# Altium schematic sheet Sheet1.SchDoc(Sheet1)
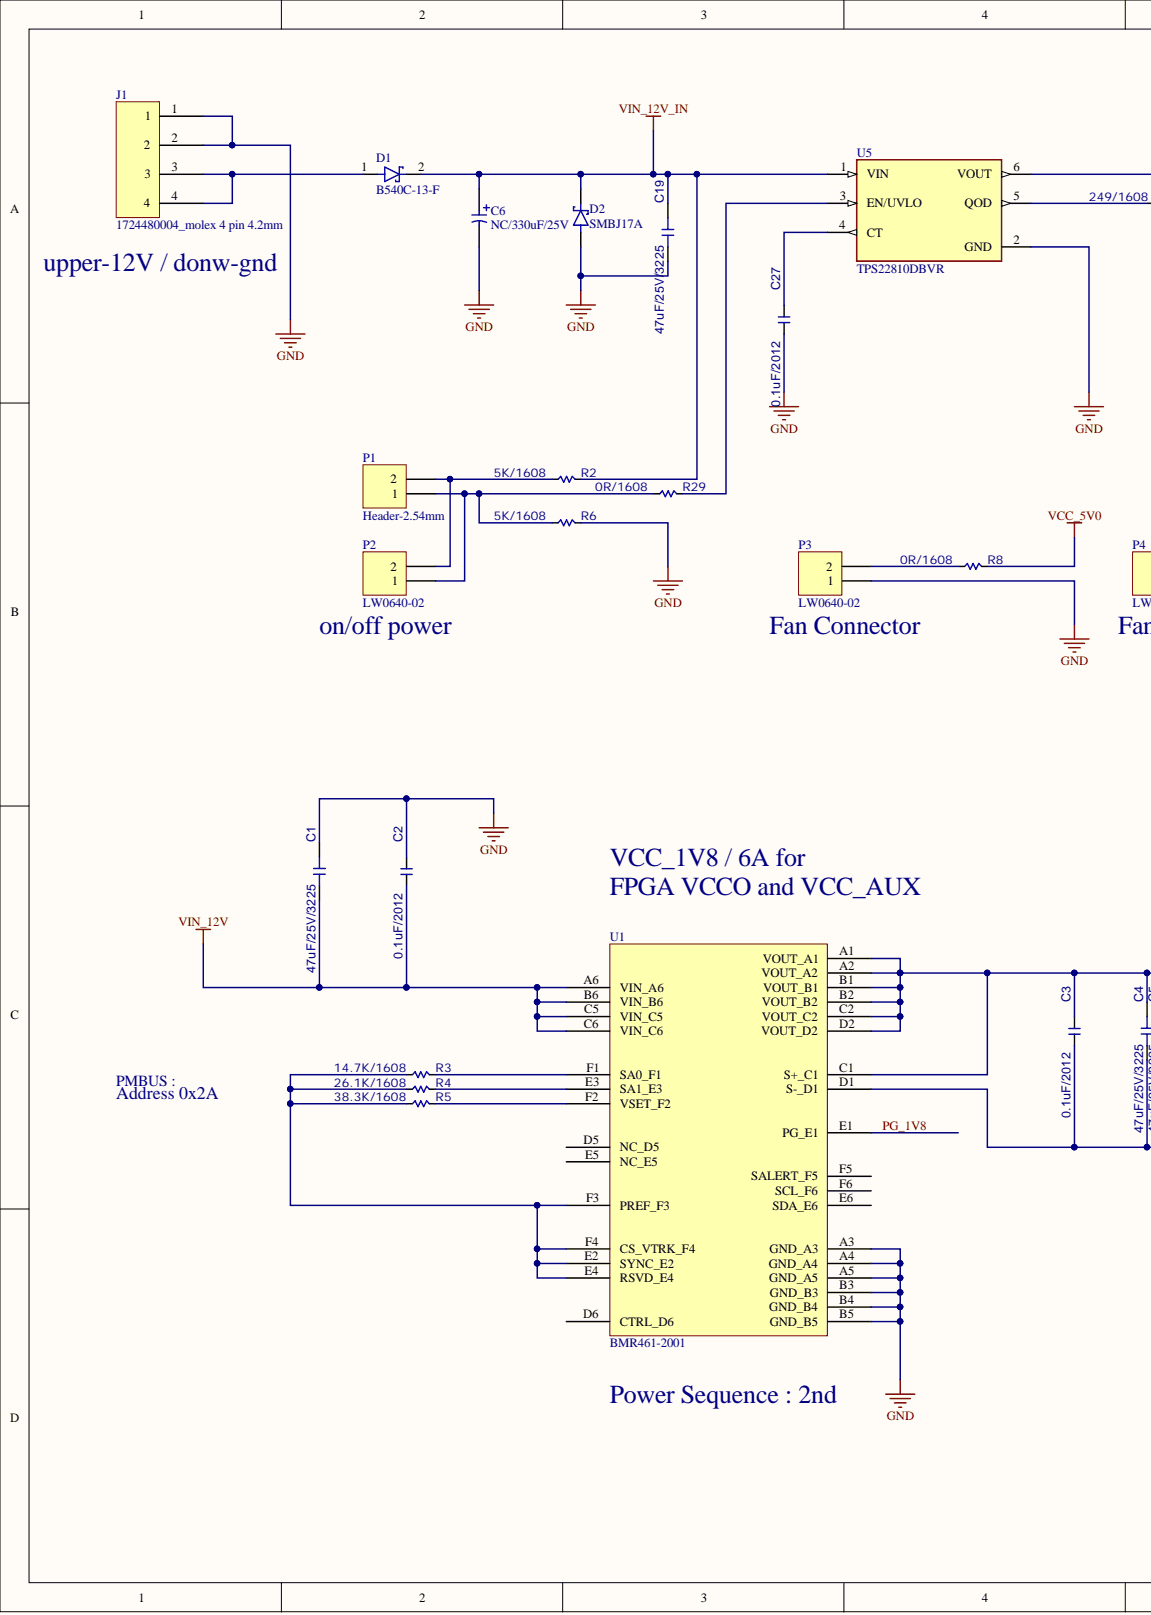
[diagram p0: Sheet1.SchDoc, left]
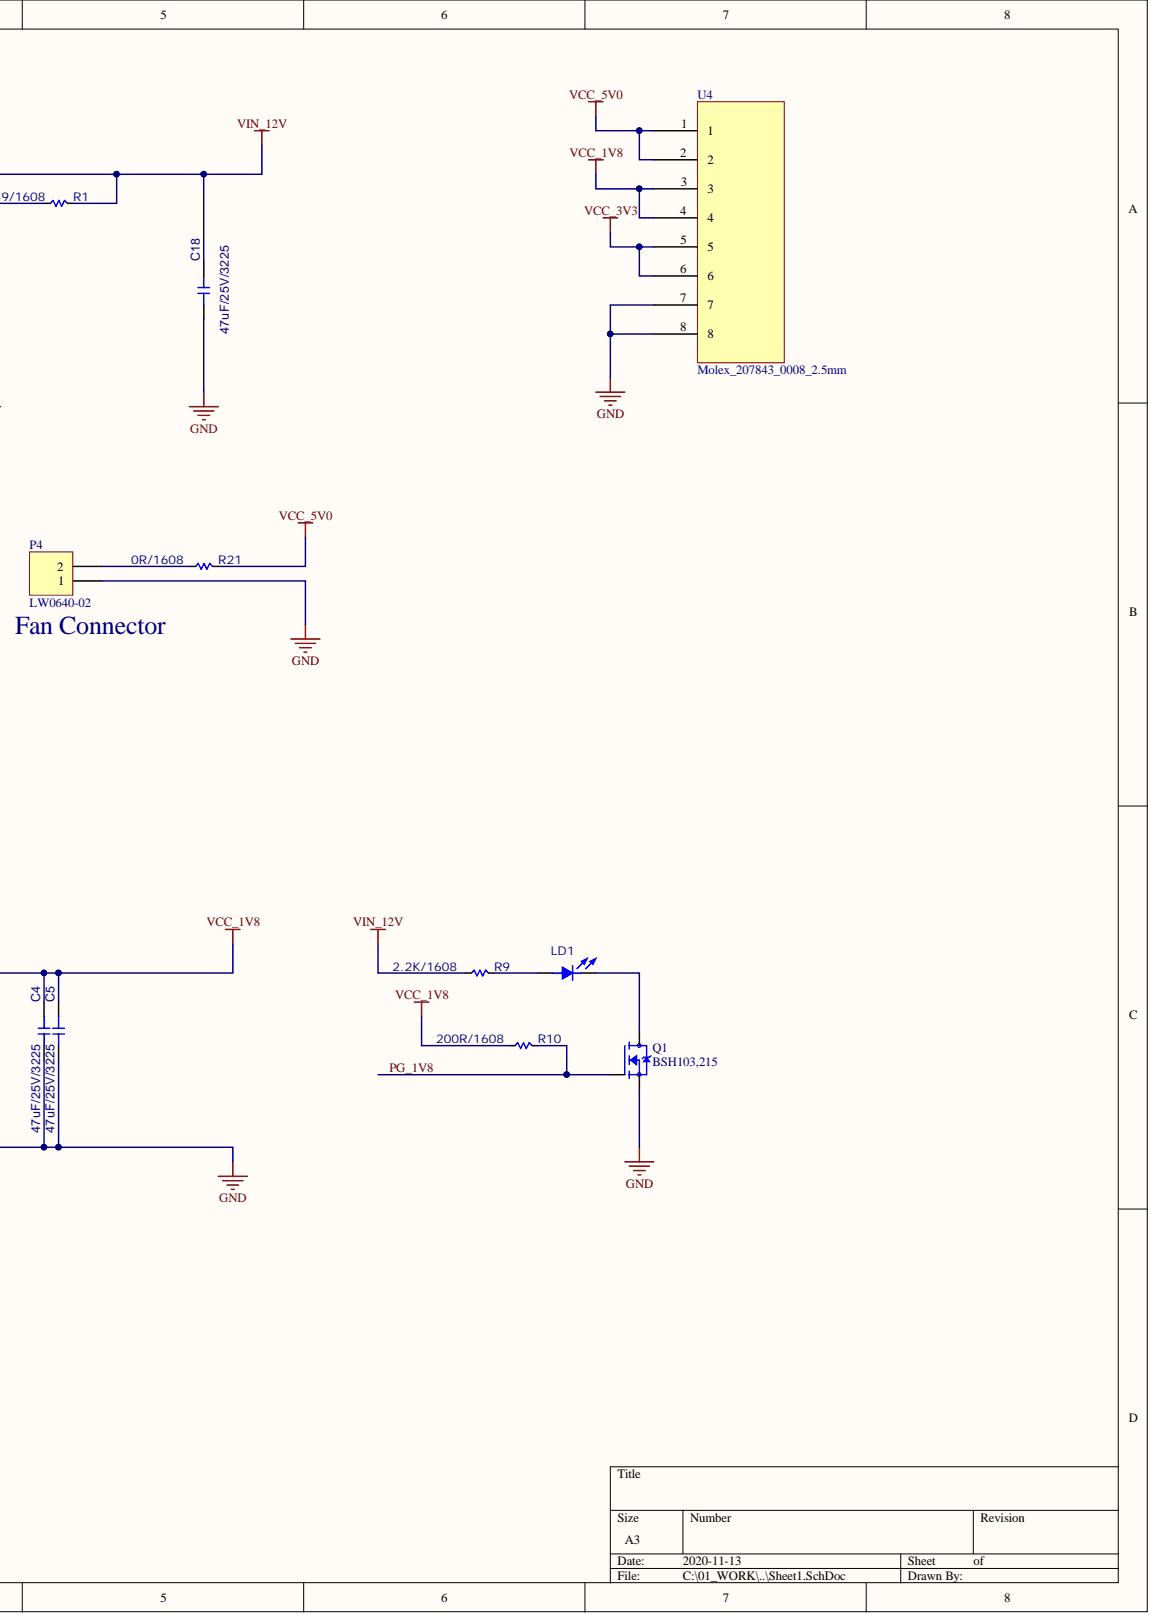
[diagram p1: Sheet1.SchDoc, right]

## Parts
C1  47uF/25V/3225  [C3225]
C2  0.1uF/2012  [C2012]
C3  0.1uF/2012  [C2012]
C4  47uF/25V/3225  [C3225]
C5  47uF/25V/3225  [C3225]
C6  NC/330uF/25V  [TC7343]
C18  47uF/25V/3225  [C3225]
C19  47uF/25V/3225  [C3225]
C27  0.1uF/2012  [C2012]
D1  B540C-13-F  [DO-214AB]
D2  SMBJ17A  [DO-214AA(SMB)]
J1  1724480004_molex 4 pin 4.2mm  [1724480004_molex 4 pin 4.2mm]
LD1  (no value)  [LED1608]
P1  Header-2.54mm  [HDR1X2]
P2  LW0640-02  [LW0640-02]
P3  LW0640-02  [LW0640-02]
P4  LW0640-02  [LW0640-02]
Q1  BSH103,215  [SOT23]
R1  249/1608  [R1608]
R2  5K/1608  [R1608]
R3  14.7K/1608  [R1608]
R4  26.1K/1608  [R1608]
R5  38.3K/1608  [R1608]
R6  5K/1608  [R1608]
R8  0R/1608  [R1608]
R9  2.2K/1608  [R1608]
R10  200R/1608  [R1608]
R21  0R/1608  [R1608]
R29  0R/1608  [R1608]
U1  BMR461-2001  [BGA36C200P6X6_1220X1220X800]
U4  Molex_207843_0008_2.5mm  [MOLEX-2078430008]
U5  TPS22810DBVR  [SOT23-6PIN]

## Nets
GND: C1.2 C2.2 C3.1 C4.1 C5.1 C6.2 C18.1 C19.1 C27.1 D2.1 J1.1 J1.2 P3.1 P4.1 Q1.2 R6.2 U1.A3(GND_A3) U1.A4(GND_A4) U1.A5(GND_A5) U1.B3(GND_B3) U1.B4(GND_B4) U1.B5(GND_B5) U1.D1(S-_D1) U4.7 U4.8 U5.2(GND)
VCC_1V8: C3.2 C4.2 C5.2 R10.1 U1.A1(VOUT_A1) U1.A2(VOUT_A2) U1.B1(VOUT_B1) U1.B2(VOUT_B2) U1.C1(S+_C1) U1.C2(VOUT_C2) U1.D2(VOUT_D2) U4.3 U4.4
VCC_3V3: U4.5 U4.6
VCC_5V0: R8.2 R21.2 U4.1 U4.2
VIN_12V: C1.1 C2.1 C18.2 R1.2 R9.1 U1.A6(VIN_A6) U1.B6(VIN_B6) U1.C5(VIN_C5) U1.C6(VIN_C6) U5.6(VOUT)
VIN_12V_IN: C6.1 C19.2 D1.2 D2.2 R2.2 U5.1(VIN)
PG_1V8: Q1.1 R10.2 U1.E1(PG_E1)
NetC27_2: C27.2 U5.4(CT)
NetD1_1: D1.1 J1.3 J1.4
NetLD1_1: LD1.1 R9.2
NetLD1_2: LD1.2 Q1.3
NetP1_1: P1.1 P2.1 R6.1 R29.1
NetP1_2: P1.2 P2.2 R2.1
NetP3_2: P3.2 R8.1
NetP4_2: P4.2 R21.1
NetR1_1: R1.1 U5.5(QOD)
NetR3_1: R3.1 R4.1 R5.1 U1.E2(SYNC_E2) U1.E4(RSVD_E4) U1.F3(PREF_F3) U1.F4(CS_VTRK_F4)
NetR3_2: R3.2 U1.F1(SA0_F1)
NetR4_2: R4.2 U1.E3(SA1_E3)
NetR5_2: R5.2 U1.F2(VSET_F2)
NetR29_2: R29.2 U5.3(EN/UVLO)

Not connected: U1 D5(NC_D5) D6(CTRL_D6) E5(NC_E5) E6(SDA_E6) F5(SALERT_F5) F6(SCL_F6)

## Library data of the parts
C1 C4 C5 C18 C19 = C3225: Chip Capacitor Ceramic; Value: uF; Component Kind: Standard; Library Name: zerom_mm.SchLib
C2 C3 C27 = C2012: Chip Capacitor Ceramic; Value: uF; Component Kind: Standard; Library Name: zerom_mm.SchLib
C6 = TC7343: Tantalum Capacitor (Surface Mount); Value: uF; Component Kind: Standard; Library Name: zerom.SchLib
D1 D2 = B540C-13-F: Diode Schottky 40V 5A Surface Mount SMC; MF: Multicomp; SnapEDA_Link: https://www.snapeda.com/parts/B540C-13-F/Multicomp/view-part/588851/?ref=snap; Price: None; Package: DO-214 Multicomp; MP: B540C-13-F; Library Name: B540C-13-F.IntLib; Component Kind: Standard; Availability: Unavailable
J1 = 1724480004: Library Name: 1724480004.SchLib; Component Kind: Standard
LD1 = LED1608: Chip LED Lamp; Component Kind: Standard; Library Name: zerom_mm.SchLib
P1-P4 = Header 2: Header, 2-Pin; Signal Integrity: Connector; Library Name: Miscellaneous Connectors.IntLib; LatestRevisionNote: Re-released for DXP Platform.; LatestRevisionDate: 17-Jul-2002; Component Kind: Standard; Publisher: Altium Limited
Q1 = BSH103,215: N-Channel 30V 850mA _Ta_ 540mW _Ta_ Surface Mount TO-236AB; MF: Nexperia USA; SnapEDA_Link: https://www.snapeda.com/parts/BSH103,215/Nexperia%20USA%20Inc./view-part/624233/?ref=snap; Price: None; Package: SOT-23-3 Nexperia; MP: BSH103,215; Library Name: BSH103_215.IntLib; Component Kind: Standard; Availability: Unavailable
R1-R6 R8-R10 R21 R29 = R1608: Chip Resistor; Value: OHM; Component Kind: Standard; Library Name: zerom_mm.SchLib
U4 = Molex_207843_0008: Library Name: Molex_207843_0008.SchLib; Component Kind: Standard
U5 = TPS22810DBVR: 18-V, 3-A, 79-m&Omega; load switch with adj. rise time and adj. output discharge 6-SOT-23 -40 to 105; Price: None; Package: None; MP: TPS22810DBVR; Library Name: TPS22810DBVR.SchLib; Component Kind: Standard; Availability: Unavailable
U1 = BMR461-2001: Library Name: BMR461-2001.SchLib; Component Kind: Standard
